# Altium schematic sheet Module Hub.SchDoc("Module Hub")
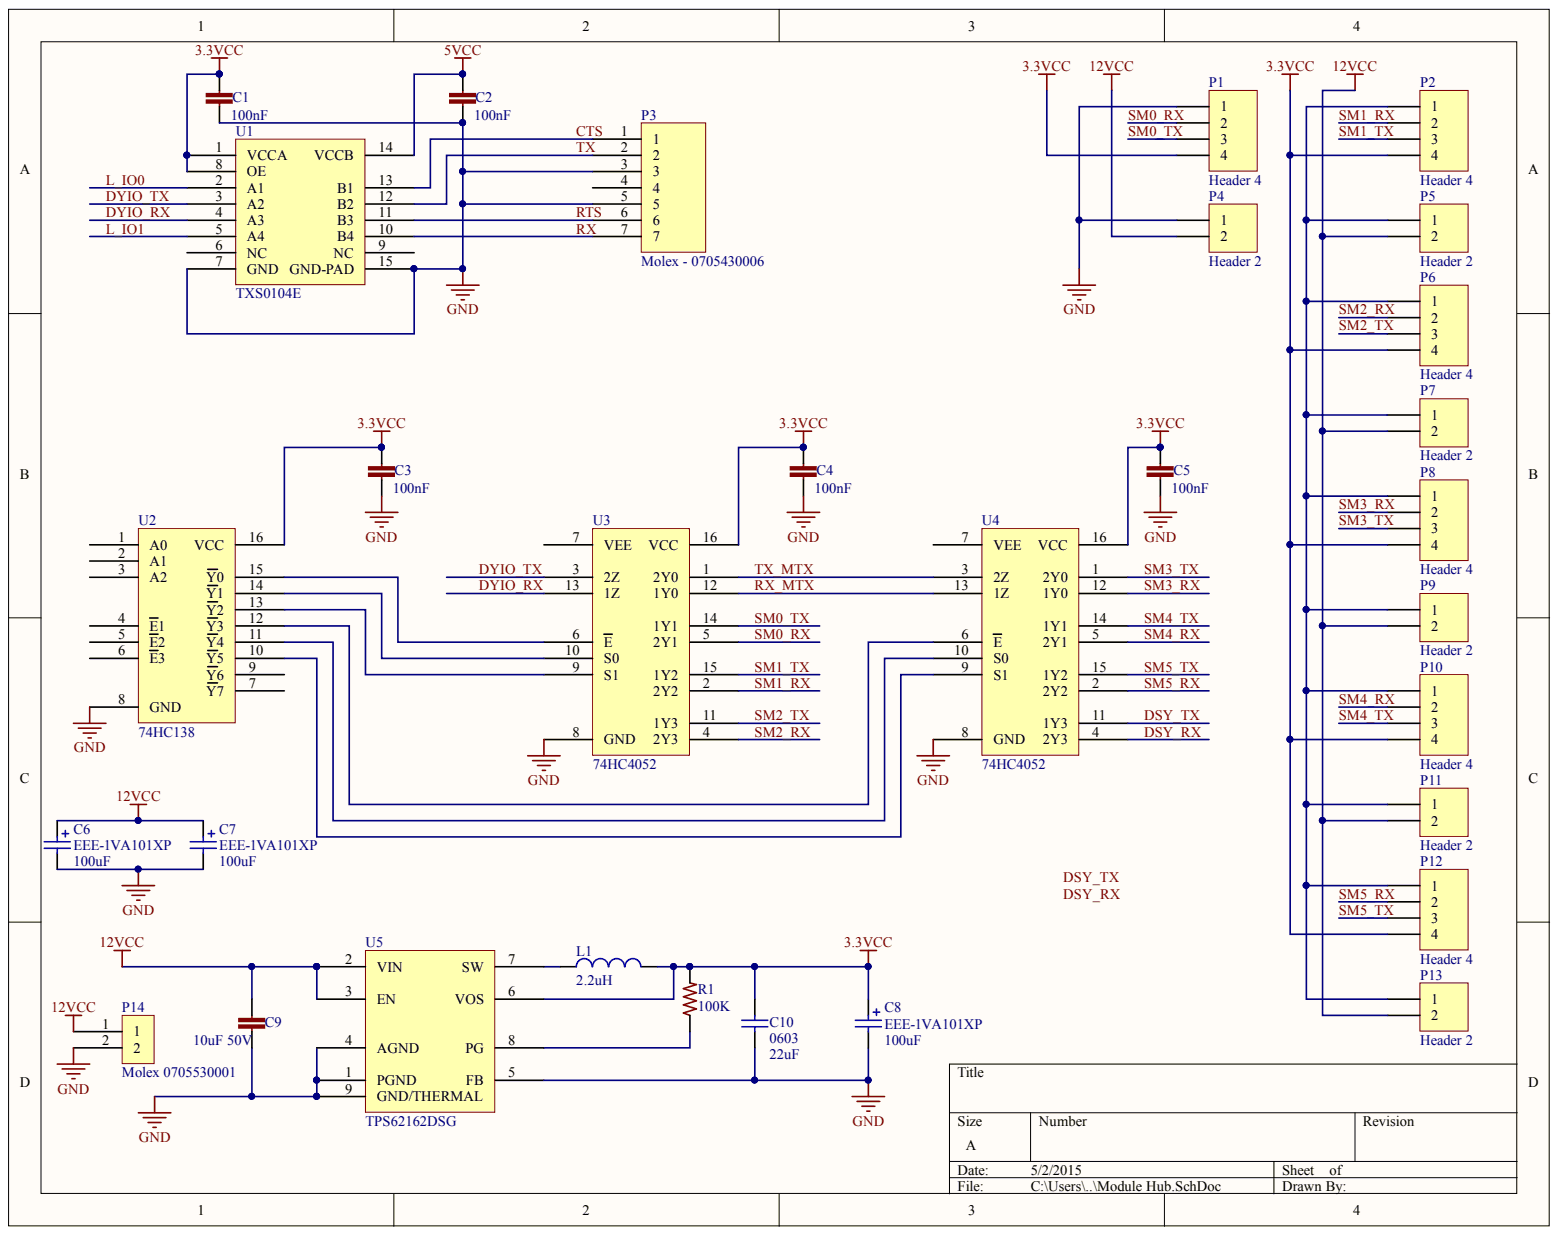
[diagram p0: Module Hub.SchDoc]

## Parts
C1  100nF  [C0603]
C2  100nF  [C0603]
C3  100nF  [C0603]
C4  100nF  [C0603]
C5  100nF  [C0603]
C6  EEE-1VA101XP  value 100uF  [EEE-1VA101XP]
C7  EEE-1VA101XP  value 100uF  [EEE-1VA101XP]
C8  EEE-1VA101XP  value 100uF  [EEE-1VA101XP]
C9  10uF 50V  [C1206]
C10  0603  value 22uF  [C0805]
L1  value 2.2uH  [VLS252012T-2R2M1R3]  (hidden comment: VLS252012T-2R2M1R3)
P1  Header 4  [HDR1X4]
P2  Header 4  [HDR1X4]
P3  Molex - 0705430006  MFR: Molex  [0705530041]
P4  Header 2  [HDR1X2]
P5  Header 2  [HDR1X2]
P6  Header 4  [HDR1X4]
P7  Header 2  [HDR1X2]
P8  Header 4  [HDR1X4]
P9  Header 2  [HDR1X2]
P10  Header 4  [HDR1X4]
P11  Header 2  [HDR1X2]
P12  Header 4  [HDR1X4]
P13  Header 2  [HDR1X2]
P14  Molex 0705530001  MFR: Molex  [0705530001]
R1  100K  [R0603]
U1  TXS0104E  [RGY  (S-PVQFN-N14)]
U2  74HC138  [74HC138]
U3  74HC4052  [Model Name]
U4  74HC4052  [Model Name]
U5  TPS62162DSG  [DSG8-1600X900TP]

## Nets
3.3VCC: C1.1 C3.1 C4.1 C5.1 C8.1 C10.2 L1.2 P1.4 P2.4 P6.4 P8.4 P10.4 P12.4 R1.2 U1.1(VCCA) U1.8(OE) U2.16(VCC) U3.16(VCC) U4.16(VCC) U5.6(VOS)
5VCC: C2.1 U1.14(VCCB)
12VCC: C6.1 C7.1 C9.1 P4.2 P5.2 P7.2 P9.2 P11.2 P13.2 P14.1 U5.2(VIN) U5.3(EN)
GND: C1.2 C2.2 C3.2 C4.2 C5.2 C6.2 C7.2 C8.2 C9.2 C10.1 P1.1 P3.3 P3.5 P4.1 P14.2 U1.7(GND) U1.15(GND-PAD) U2.8(GND) U3.8(GND) U4.8(GND) U5.1(PGND) U5.4(AGND) U5.5(FB) U5.9(GND/THERMAL)
CTS: P3.1 U1.13(B1)
DSY_RX: U4.4(2Y3)
DSY_TX: U4.11(1Y3)
DYIO_RX: U1.4(A3) U3.13(1Z)
DYIO_TX: U1.3(A2) U3.3(2Z)
L_IO0: U1.2(A1)
L_IO1: U1.5(A4)
RTS: P3.6 U1.11(B3)
RX: P3.7 U1.10(B4)
RX_MTX: U3.12(1Y0) U4.13(1Z)
SM0_RX: P1.2 U3.5(2Y1)
SM0_TX: P1.3 U3.14(1Y1)
SM1_RX: P2.2 U3.2(2Y2)
SM1_TX: P2.3 U3.15(1Y2)
SM2_RX: P6.2 U3.4(2Y3)
SM2_TX: P6.3 U3.11(1Y3)
SM3_RX: P8.2 U4.12(1Y0)
SM3_TX: P8.3 U4.1(2Y0)
SM4_RX: P10.2 U4.5(2Y1)
SM4_TX: P10.3 U4.14(1Y1)
SM5_RX: P12.2 U4.2(2Y2)
SM5_TX: P12.3 U4.15(1Y2)
TX: P3.2 U1.12(B2)
TX_MTX: U3.1(2Y0) U4.3(2Z)
NetL1_1: L1.1 U5.7(SW)
NetP2_1: P2.1 P5.1 P6.1 P7.1 P8.1 P9.1 P10.1 P11.1 P12.1 P13.1
NetR1_1: R1.1 U5.8(PG)
NetU2_10: U2.10(Y5) U4.9(S1)
NetU2_11: U2.11(Y4) U4.10(S0)
NetU2_12: U2.12(Y3) U4.6(E)
NetU2_13: U2.13(Y2) U3.9(S1)
NetU2_14: U2.14(Y1) U3.10(S0)
NetU2_15: U2.15(Y0) U3.6(E)

Not connected: P3 4; U1 6(NC) 9(NC); U2 1(A0) 2(A1) 3(A2) 4(E1) 5(E2) 6(E3) 7(Y7) 9(Y6); U3 7(VEE); U4 7(VEE)

## Library data of the parts
C1-C5 = C0603C104K5RACTU: CAP CER 0603 100nF 50V 10% X7R; LastRevisionNote: ImportComponents; Component Kind: Standard; LastRevisionDate: 23-Apr-2014; Library Name: 3Delight.SCHLIB; ManufacturerPartNumber: C0603C104K5RACTU; Published: 23-Apr-2014; Signal Integrity: Model Name; Simulation: Model Name; VALUE: 100nF; Publisher: UltiMachine
C6-C8 = EEE-1VA101XP: CAP ALUM 100UF 35V 20% SMD; Manufacture: Panasonic; Component Kind: Standard; Datasheet: http://industrial.panasonic.com/www-cgi/jvcr13pz.cgi?E+PZ+3+ABA0002+EEE1VA101XP+7+WW; Digikey Part #: PCE3951CT-ND; Height: 7.70mm; Library Name: Tigershark3D.SchLib; Manufacture Part #: EEE-1VA101XP; Mount Type: SMT Panasonic VS D Series; Price: $0.63; Signal Integrity: Cap Pol; Simulation: CAP; Status: Active
C9 = CL31A106KBHNNNE: CAP CER 10UF 50V 10% X5R 1206; Manufacturer: Samsung; LastRevisionNote: ImportComponents; Component Kind: Standard; LastRevisionDate: 25-mar-2015; Library Name: Tigershark3D.SchLib; ManufacturerPartNumber: CL31A106KBHNNNE; Published: 25-mar-2015; Signal Integrity: Model Name; Simulation: Model Name; VALUE: 10uF 50V; Publisher: UltiMachine
C10 = CL21A226KQQNNNE: CAP CER 22UF 6.3V 10% X5R 0805; MFR: Samsung; Voltage: 6.3VDC; Component Kind: Standard; Component Type: Chip Capacitor; Datasheet: http://www.digikey.com/product-detail/en/CL21A226KQQNNNE/1276-2907-2-ND/3888565; Digikey #: 1276-2907-2-ND; Height: 1mm; LatestRevisionDate: April 2015; Library Name: Sensor Package.SchLib; MFR Part #: CL21A226KQQNNNE; Mounting Type: SMT 0805; PackageReference: 0805; Part Description: CAP CER 22UF 6.3V 10% X5R 0805; Published: April 2015; Signal Integrity: Cap Semi; Simulation: CAP; Tolerance: 10%
L1 = VLS252012T-2R2M1R3: MFR: TDK Corporation; Component Kind: Standard; Description: VLS252012T-2R2M1R3; LatestRevisionDate: April 2015; LatestRevisionNote: IPC-7351 Footprint Added.; Library Name: Sensor Package.SchLib; MFR#: VLS252012T-2R2M1R3; PackageDescription: Wire Wound Inductor Package; PackageReference: Custom Wound INductor; Published: April 2015; Signal Integrity: Inductor; Publisher: Adam Vadala-Roth
P1 P2 P6 P8 P10 P12 = Header 4: Header, 4-Pin; Component Kind: Standard; LatestRevisionDate: 17-Jul-2002; LatestRevisionNote: Re-released for DXP Platform.; Library Name: Miscellaneous Connectors.IntLib; Signal Integrity: Connector; Publisher: Altium Limited
P3 = 0705530041: Component Kind: Standard; Description: 0705530041; Library Name: Sensor Package.SchLib; MFR#: 0705530041
P4 P5 P7 P9 P11 P13 = Header 2: Header, 2-Pin; Component Kind: Standard; LatestRevisionDate: 17-Jul-2002; LatestRevisionNote: Re-released for DXP Platform.; Library Name: Miscellaneous Connectors.IntLib; Signal Integrity: Connector; Publisher: Altium Limited
P14 = 0705530001: Molex 0705530002 Right Angle 2.54mm Shrouded Header; Component Kind: Standard; Digikey #: WM4900-ND; Library Name: Sensor Package.SchLib; MFR#: 0705530001; Type: 2.54mm shrouded 2 pin right angle throughhole connector
R1 = RC0603FR-07100KL: RES 100K OHM 1/10W 1% 0603 SMD; LastRevisionNote: ImportComponents; Component Kind: Standard; LastRevisionDate: 23-Apr-2014; Library Name: 3Delight.SCHLIB; ManufacturerPartNumber: RC0603FR-07100KL; Published: 23-Apr-2014; Signal Integrity: Model Name; Simulation: Model Name; VALUE: 100K; Publisher: UltiMachine
U1 = TXS0104E: TXS0104E - Bidriectional Opendrain/pushpull level shift; MFR: Texas Instruments; MFR Part #: TXS0104ERGYR; Active: Yes; Component Kind: Standard; Datasheet: http://www.ti.com/lit/ds/symlink/txs0104e.pdf; Digikey Part #: 296-20698-2-ND; Height: 1mm; Lead Free ?: RhoS; Library Name: Tigershark3D.SchLib; mounting Type: SMD
U2 = 74HC138: 3-to-8 line decoder/demultiplexer; inverting; Component Kind: Standard; Library Name: Sensor Package.SchLib
U3 U4 = 74HC4052: Dual 4-channel analog multiplexer/demultiplexer; MFR: NXP; Mouting Type: SMT; Component Kind: Standard; Datasheet: http://www.nxp.com/documents/data_sheet/74HC_HCT4052.pdf; Library Name: Sensor Package.SchLib; MFR#: 74HC4052; Type: 74 logic, analog mulitplexer/demultiplexer
U5 = TPS62162SOP: Component Kind: Standard; Library Name: Adams Altium Library.SchLib
